# Altium schematic sheet synchronous-demodulator.SchDoc
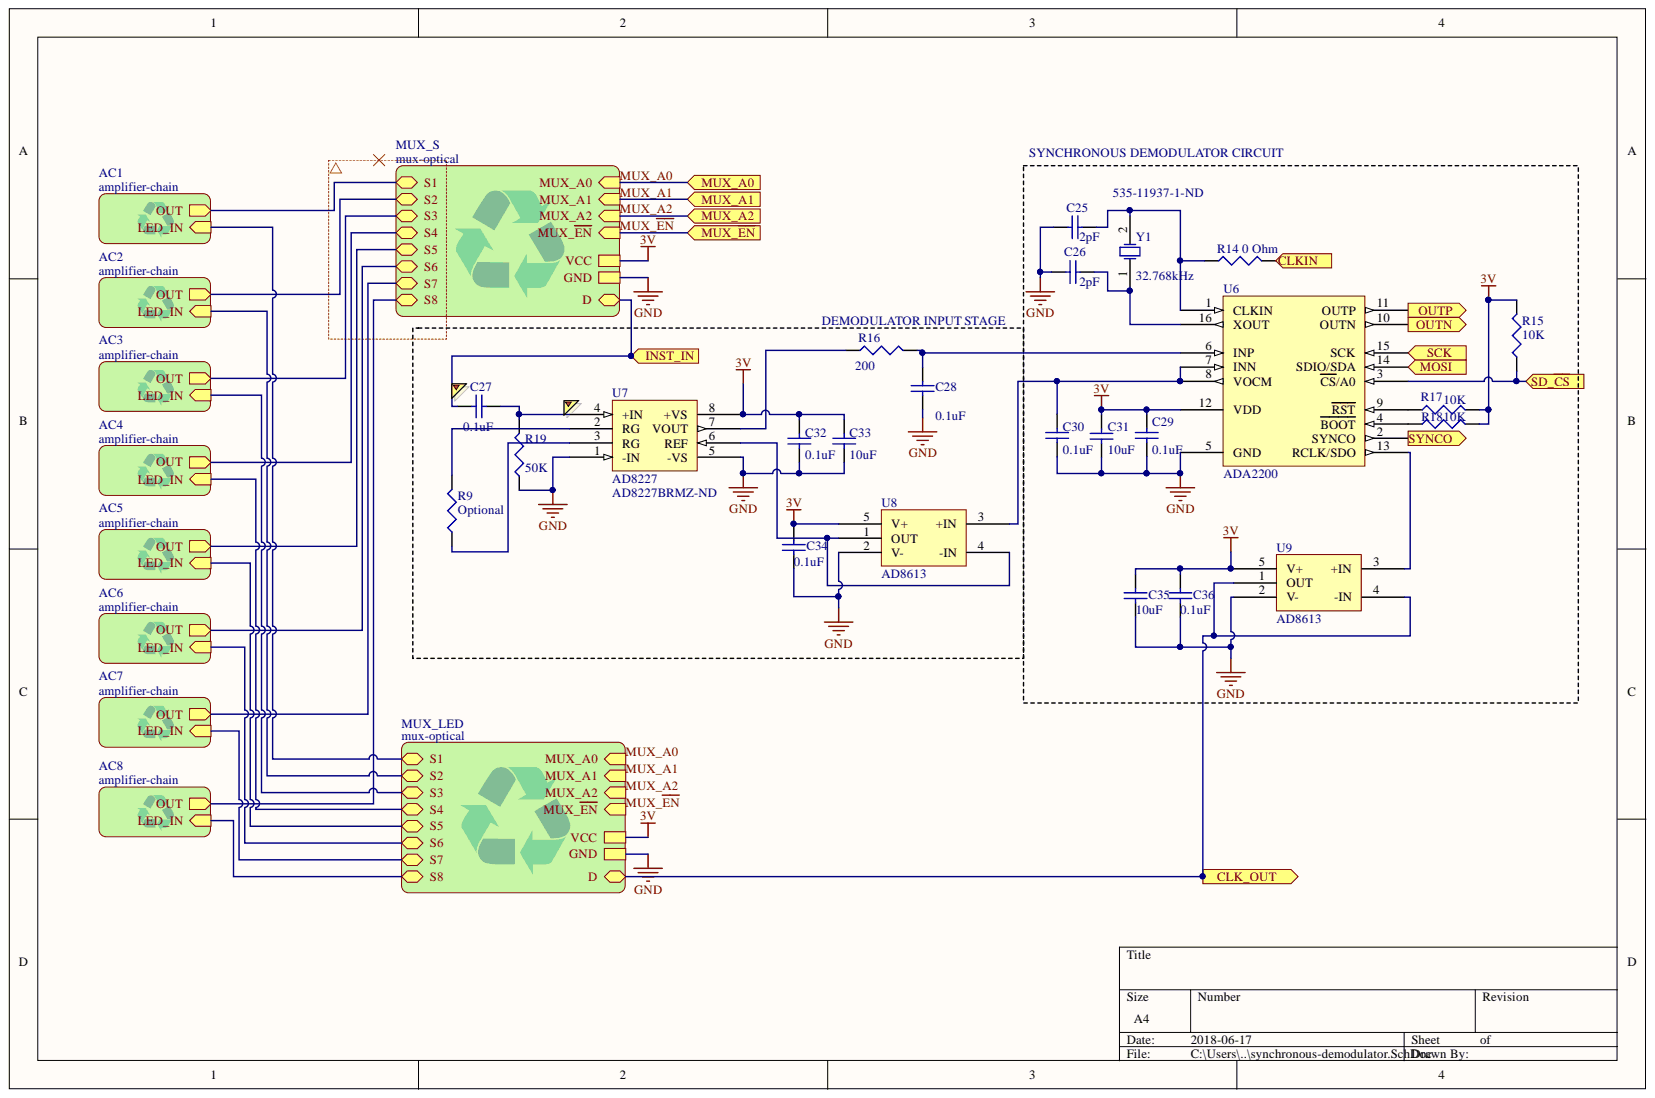
[diagram p0: synchronous-demodulator.SchDoc]

## Parts
C25  2pF  [0402]
C26  2pF  [0402]
C27  0.1uF  [0402]
C28  0.1uF  [0402]
C29  0.1uF  [0402]
C30  0.1uF  [0402]
C31  10uF  [1608[0603]]
C32  0.1uF  [0402]
C33  10uF  [1608[0603]]
C34  0.1uF  [0402]
C35  10uF  [1608[0603]]
C36  0.1uF  [0402]
R9  Optional  [0402]
R14  0 Ohm  [0402]
R15  10K  [0402]
R16  200  [0402]
R17  10K  [0402]
R18  10K  [0402]
R19  50K  [0402]
U6  ADA2200  [SSOP16_N]
U7  AD8227  Supplier Part Number 1: AD8227BRMZ-ND  [SOP8-65P300X300-8N]
U8  AD8613  [SOP-65P200X125-5N]
U9  AD8613  [SOP-65P200X125-5N]
Y1  32.768kHz  Supplier Part Number: 535-11937-1-ND  [ABS07-120-32.768]

## Ports
CLK_OUT, CLKIN, INST_IN, MOSI, MUX_A0, MUX_A1, MUX_A2, MUX_~{EN}, OUTN, OUTP, SCK, SD_~{CS}, SYNCO

## Nets
3V: C29.2 C31.1 C32.2 C33.2 C34.2 C35.2 C36.2 R15.2 R17.2 R18.2 U6.12(VDD) U7.8(+VS) U8.5(V+) U9.5(V+)
GND: C25.2 C26.2 C28.1 C29.1 C30.1 C31.2 C32.1 C33.1 C34.1 C35.1 C36.1 R19.1 U6.5(GND) U7.1(-IN) U7.5(-VS) U8.2(V-) U9.2(V-)
CLK_OUT: U9.1(OUT) U9.4(-IN) ; port CLK_OUT
CLKIN: R14.2 ; port CLKIN
INST_IN: C27.2 ; port INST_IN
MOSI: U6.14(SDIO/SDA) ; port MOSI
OUTN: U6.10(OUTN) ; port OUTN
OUTP: U6.11(OUTP) ; port OUTP
SCK: U6.15(SCK) ; port SCK
SD_~{CS}: R15.1 U6.3(CS/A0) ; port SD_~{CS}
SYNCO: U6.2(SYNCO) ; port SYNCO
NetC25_1: C25.1 R14.1 U6.1(CLKIN) Y1.2
NetC26_1: C26.1 U6.16(XOUT) Y1.1
NetC27_1: C27.1 R19.2 U7.4(+IN)
NetC28_2: C28.2 R16.2 U6.6(INP)
NetC30_2: C30.2 U6.7(INN) U6.8(VOCM) U8.3(+IN)
NetR9_1: R9.1 U7.3(RG)
NetR9_2: R9.2 U7.2(RG)
NetR16_1: R16.1 U7.7(VOUT)
NetR17_1: R17.1 U6.9(RST)
NetR18_1: R18.1 U6.4(BOOT)
NetU6_13: U6.13(RCLK/SDO) U9.3(+IN)
NetU7_6: U7.6(REF) U8.1(OUT) U8.4(-IN)
MUX_A0: ; port MUX_A0
MUX_A1: ; port MUX_A1
MUX_A2: ; port MUX_A2
MUX_~{EN}: ; port MUX_~{EN}
N00065:
N00066:
N00067:
N00068:
N00069:
N00070:
N00071:
N00072:
N00073:
N00074:
N00075:
N00076:
N00077:
N00078:
N00079:
N00080:

## Library data of the parts
C25-C36 = Cap Semi: Capacitor (Semiconductor SIM Model); Component Kind: Standard; LatestRevisionDate: 29-May-2009; LatestRevisionNote: IPC-7351 Footprint Added.; Library Name: Miscellaneous Devices.IntLib; PackageDescription: Chip Capacitor; PackageReference: C1206; Published: 8-Jun-2000; Signal Integrity: Cap Semi; Simulation: CAP; Supplier: Digi-Key; Publisher: Altium Limited
  C25 C26: Value: 2pF
  C27-C30 C32 C34 C36: Value: 0.1uF
  C31 C33 C35: Value: 10uF
R9 = Res3: Optional resistor to set gain on inst amp.; Component Kind: Standard; LatestRevisionDate: 29-May-2009; LatestRevisionNote: IPC-7351 Footprint Added.; Library Name: Miscellaneous Devices.IntLib; PackageDescription: Chip Resistor; PackageReference: J1-0603; Published: 8-Jun-2000; Signal Integrity: Res; Simulation: RESISTOR; Publisher: Altium Limited
R14-R19 = Res3: Resistor; Component Kind: Standard; LatestRevisionDate: 29-May-2009; LatestRevisionNote: IPC-7351 Footprint Added.; Library Name: Miscellaneous Devices.IntLib; PackageDescription: Chip Resistor; PackageReference: J1-0603; Published: 8-Jun-2000; Signal Integrity: Res; Simulation: RESISTOR; Supplier: Digi-Key; Publisher: Altium Limited
  R14: Value: 0 Ohm
  R15 R17 R18: Value: 10K
  R16: Value: 200
  R19: Value: 50K
U6 = ADA2200: Synchronous demodulator and configurable analog filter.; Component Kind: Standard; Supplier: Digi-Key; Library Name: PAY.SchLib
U7 = AD8227: Rail-to-rail instrumentation amplifier. Can operate on single supply.; Component Kind: Standard; Supplier: Digi-Key; Supplier 1: Digi-Key; Library Name: PAY.SchLib
U8 U9 = AD8613: Low power, low noise rail-to-rail op-amp.; Component Kind: Standard; Supplier: Digi-Key; Library Name: PAY.SchLib
Y1 = XTAL: Crystal Oscillator; Component Kind: Standard; LatestRevisionDate: 17-Jul-2002; LatestRevisionNote: Re-released for DXP Platform.; Library Name: Miscellaneous Devices.IntLib; PackageDescription: Crystal, Thru-Hole; 2 Leads; Body 3.1 x 8.2 mm (Dia.xH); PackageReference: R38; Published: 8-Jun-2000; Simulation: XTAL; Supplier: Digi-Key; Publisher: Altium Limited
